# Altium schematic sheet FPGA-Misc.SchDoc(FPGA-Misc)
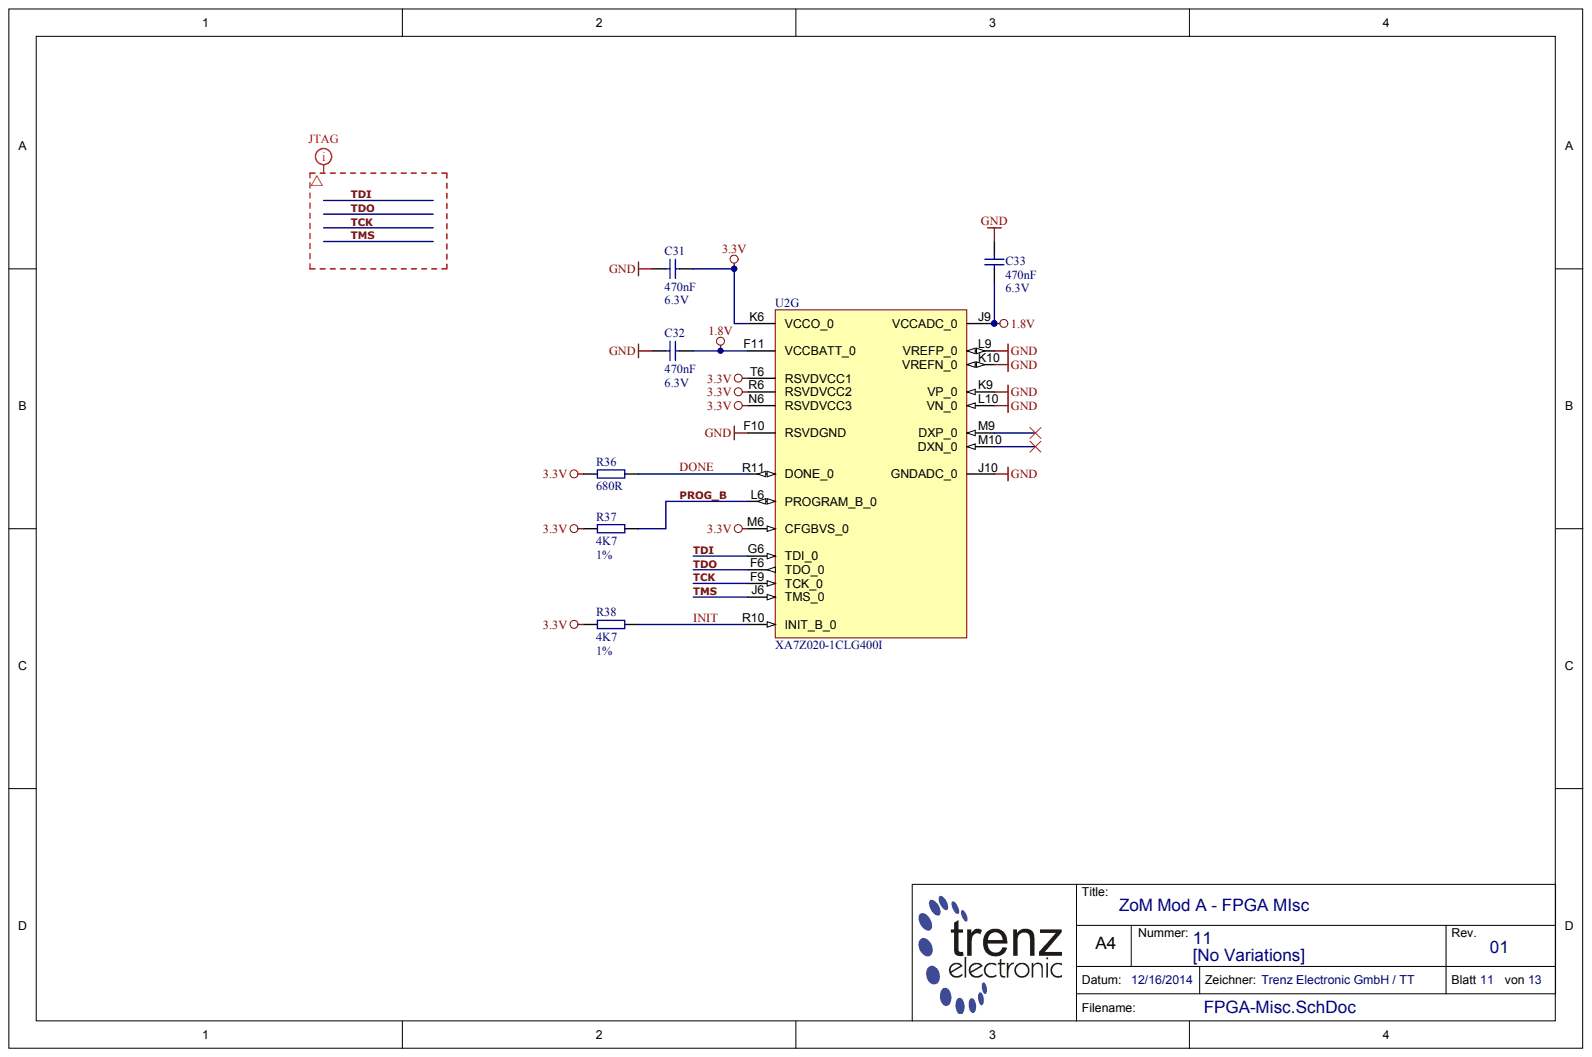
[diagram p0: FPGA-Misc.SchDoc]

## Parts
C31  470nF  Voltage: 6.3V  [CAPC0603X33N]
C32  470nF  Voltage: 6.3V  [CAPC0603X33N]
C33  470nF  Voltage: 6.3V  [CAPC0603X33N]
R36  680R  [RESC1005X40N]
R37  4K7  Tolerance: 1%  [RESC0603X26N]
R38  4K7  Tolerance: 1%  [RESC0603X26N]
U2  XA7Z020-1CLG400I  [BGA400C80P20X20_1700X1700X160N]  (part G)

## Nets
1.8V: C32.2 C33.2 U2.F11(VCCBATT_0) U2.J9(VCCADC_0)
3.3V: C31.2 R36.1 R37.2 R38.2 U2.K6(VCCO_0) U2.M6(CFGBVS_0) U2.N6(RSVDVCC3) U2.R6(RSVDVCC2) U2.T6(RSVDVCC1)
GND: C31.1 C32.1 C33.1 U2.F10(RSVDGND) U2.J10(GNDADC_0) U2.K9(VP_0) U2.K10(VREFN_0) U2.L9(VREFP_0) U2.L10(VN_0)
DONE: R36.2 U2.R11(DONE_0)
INIT: R38.1 U2.R10(INIT_B_0)
PROG_B: R37.1 U2.L6(PROGRAM_B_0)
TCK: U2.F9(TCK_0)
TDI: U2.G6(TDI_0)
TDO: U2.F6(TDO_0)
TMS: U2.J6(TMS_0)
N00214:

Not connected: U2 M9(DXP_0) M10(DXN_0)

## Library data of the parts
C31-C33 = 24921: KON 470nF X5R 6.3V SMD; Database Table Name: C_0603_0201; Mixed: SMT; Preferred: Yes; Tolerance: 20%; Value: 470n; AN: 24921; Case: 0603 (0201); Component Kind: Standard; Library Name: TE.DbLib; Technology: X5R
R36 = 22761: WID SMD 680R 1% 0,063W; Database Table Name: R_1005_0402; Mixed: SMT; Preferred: Yes; Value: 680; AN: 22761; Case: 1005 (0402); Component Kind: Standard; Library Name: TE.DbLib
R37 R38 = 25242: WID SMD 4K7 1% 0,05W; Database Table Name: R_0603_0201; Mixed: SMT; Preferred: Yes; Value: 4.7K; AN: 25242; Case: 0603 (0201); Component Kind: Standard; Library Name: TE.DbLib; HelpURL: B:\AD\adlib\datasheet\R0201
U2 = 25981: Database Table Name: A_PROG_LOGIC; Mixed: SMT; Trenz-Stock: Yes; AN: 25981; Case: CLG400; Component Kind: Standard; Description: XA7Z020-1CLG400I; Library Name: TE.DbLib; HelpURL: B:\AD\adlib\datasheet\XC7
